# Altium schematic sheet p3_intf_conn_pwr.SchDoc(p3_intf_conn_pwr)
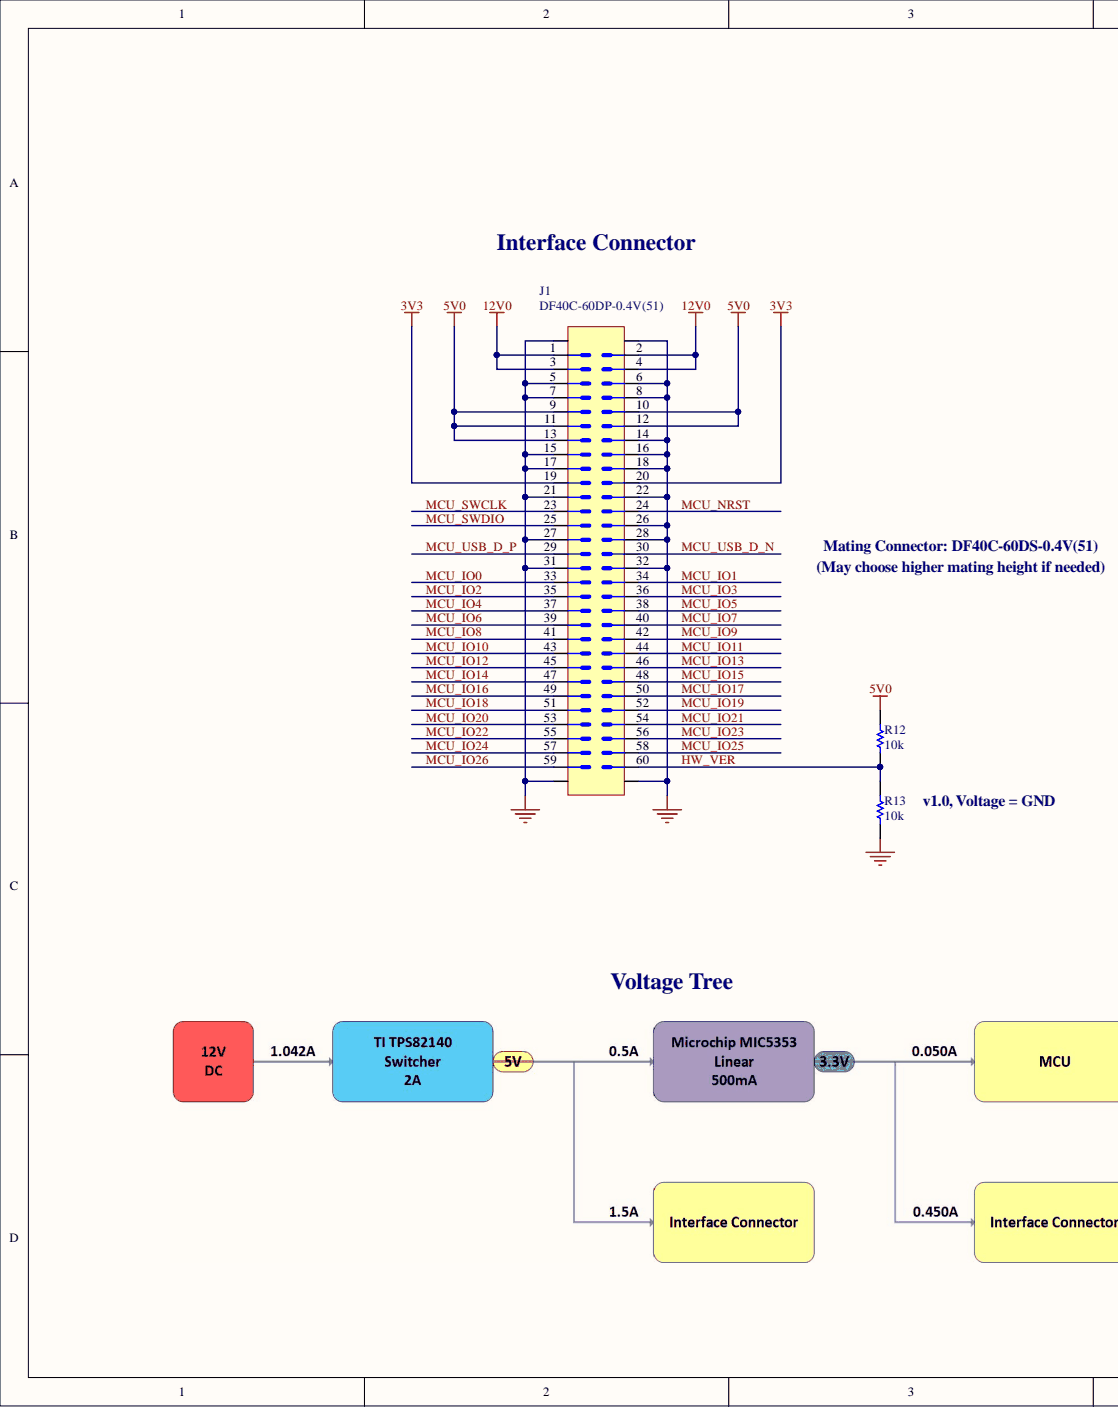
[diagram p0: p3_intf_conn_pwr.SchDoc, left]
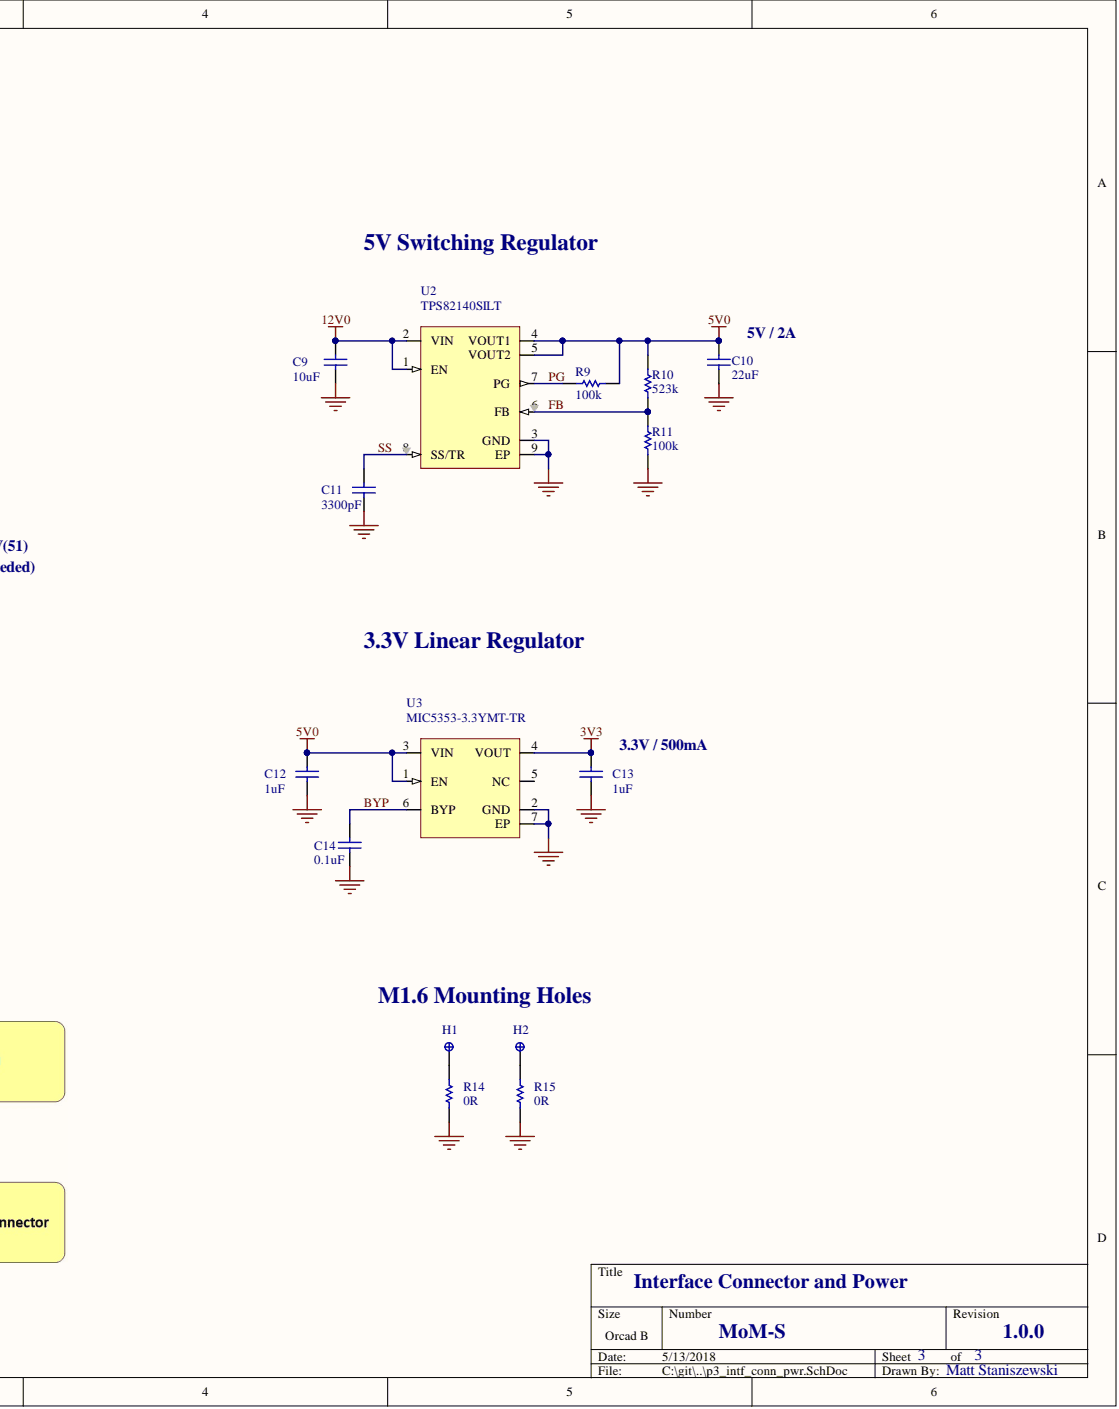
[diagram p1: p3_intf_conn_pwr.SchDoc, right]

## Parts
C9  10uF  [C0603]
C10  22uF  [C0603]
C11  3300pF  [C0201]
C12  1uF  [C0402]
C13  1uF  [C0402]
C14  0.1uF  [C0201]
H1  M1.6 MNT HOLE  [MNT_HOLE_M1.6]  (value not drawn)
H2  M1.6 MNT HOLE  [MNT_HOLE_M1.6]  (value not drawn)
J1  DF40C-60DP-0.4V(51)  [HRS_DF40C_60P_PLG]
R9  100k  [R0201]
R10  523k  [R0201]
R11  100k  [R0201]
R12  10k  [R0201]
R13  10k  [R0201]
R14  0R  [R0201]
R15  0R  [R0201]
U2  TPS82140SILT  [TI_USIL8_SIL0008D]
U3  MIC5353-3.3YMT-TR  [MCP_MLF6_MT]

## Nets
3V3: C13.2 J1.19 J1.20 U3.4(VOUT)
5V0: C10.2 C12.2 J1.9 J1.10 J1.11 J1.12 J1.13 R9.2 R10.2 R12.2 U2.4(VOUT1) U2.5(VOUT2) U3.1(EN) U3.3(VIN)
12V0: C9.2 J1.1 J1.2 J1.3 J1.4 U2.1(EN) U2.2(VIN)
GND: C9.1 C10.1 C11.1 C12.1 C13.1 C14.1 J1.5 J1.6 J1.7 J1.8 J1.14 J1.15 J1.16 J1.17 J1.18 J1.21 J1.22 J1.26 J1.27 J1.28 J1.31 J1.32 J1.61 J1.62 J1.63 J1.64 R11.1 R13.1 R14.1 R15.1 U2.3(GND) U2.9(EP) U3.2(GND) U3.7(EP)
BYP: C14.2 U3.6(BYP)
FB: R10.1 R11.2 U2.6(FB)
HW_VER: J1.60 R12.1 R13.2
MCU_IO0: J1.33
MCU_IO1: J1.34
MCU_IO2: J1.35
MCU_IO3: J1.36
MCU_IO4: J1.37
MCU_IO5: J1.38
MCU_IO6: J1.39
MCU_IO7: J1.40
MCU_IO8: J1.41
MCU_IO9: J1.42
MCU_IO10: J1.43
MCU_IO11: J1.44
MCU_IO12: J1.45
MCU_IO13: J1.46
MCU_IO14: J1.47
MCU_IO15: J1.48
MCU_IO16: J1.49
MCU_IO17: J1.50
MCU_IO18: J1.51
MCU_IO19: J1.52
MCU_IO20: J1.53
MCU_IO21: J1.54
MCU_IO22: J1.55
MCU_IO23: J1.56
MCU_IO24: J1.57
MCU_IO25: J1.58
MCU_IO26: J1.59
MCU_NRST: J1.24
MCU_SWCLK: J1.23
MCU_SWDIO: J1.25
MCU_USB_D_N: J1.30
MCU_USB_D_P: J1.29
PG: R9.1 U2.7(PG)
SS: C11.2 U2.8(SS/TR)
NetH1_1: H1.1 R14.2
NetH2_1: H2.1 R15.2

Not connected: U3 5(NC)

## Library data of the parts
C9 = 10uF 25V 0603: CAP CER 10UF 25V X5R 0603; Manufacturer Part Number: GRM188R61E106MA73D; Manufacturer: Murata; Tolerance: 20%; Width: 0.031inch; Weight: 0.000222oz; Voltage Rating (DC): 25V; Voltage Rating: 25V; Voltage: 25V; Thickness: 0.031inch; Termination: SMD/SMT; Supplier Part Number 1: 490-7202-1-ND; Supplier 1: Digi-Key; Stock 1: 1088965; REACH SVHC: No SVHC; Pricing 1: 1=0.41, 10=0.285, 100=0.1708, 500=0.1281, 1000=0.1082 (USD); Packaging: Tape and Reel; Package Quantity: 1; Number of Pins: 2; Mount: Surface Mount; Min Operating Temperature: -55°C; Max Operating Temperature: 85°C; Library Name: Capacitors.SchLib; Length: 1.6mm; Lead Free: Lead Free; Height: 0.8mm; Dielectric: X5R; Depth: 0.8mm; Composition: Ceramic; ComponentLink5URL: https://datasheet.ciiva.com/14906/partnumbering-e-01-14906431.pdf; ComponentLink5Description: https://datasheet.ciiva.com/14906/partnumbering-e-01-14906431.pdf; ComponentLink4URL: https://datasheet.ciiva.com/14906/partnumbering-e-01-14906429.pdf; ComponentLink4Description: https://datasheet.ciiva.com/14906/partnumbering-e-01-14906429.pdf; ComponentLink3URL: https://datasheet.ciiva.com/22776/c02e-22776427.pdf; ComponentLink3Description: https://datasheet.ciiva.com/22776/c02e-22776427.pdf; ComponentLink2URL: https://datasheet.ciiva.com/22776/608985-22776426.pdf; ComponentLink2Description: https://datasheet.ciiva.com/22776/608985-22776426.pdf; ComponentLink1URL: https://datasheet.ciiva.com/22619/c02e-22619674.pdf; ComponentLink1Description: https://datasheet.ciiva.com/22619/c02e-22619674.pdf; Component Kind: Standard; Case Code (Metric): 1608; Case Code (Imperial): 0603; Case/Package: 0603; Capacitance: 10uF
C10 = 22uF 16V 0603: CAP CER 22UF 16V X5R 0603; Manufacturer Part Number: CL10A226MO7JZNC; Manufacturer: Samsung; Supplier Part Number 1: 1276-7076-1-ND; Supplier 1: Digi-Key; Stock 1: 0; Library Name: Capacitors.SchLib; Component Kind: Standard
C11 = 3300pF 16V 0201: CAP CER 3300PF 16V X7R 0201; Manufacturer Part Number: GRM033R71C332KA88D; Manufacturer: Murata; Tolerance: 10%; Material: Ceramic; Capacitance: 3.3nF; Width: 0.012inch; Voltage Rating (DC): 16V; Voltage Rating: 16V; Thickness: 0.013inch; Termination: SMD/SMT; Supplier Part Number 1: 490-3191-1-ND; Supplier 1: Digi-Key; Stock 1: 49387; Radiation Hardening: No; Pricing 1: 1=0.1, 10=0.029, 100=0.013, 500=0.0093, 1000=0.0073, 2500=0.0067, 5000=0.0061 (USD); Packaging: Tape and Reel; Package Quantity: 1; Mount: Surface Mount; Min Operating Temperature: -55°C; Max Operating Temperature: 125°C; Library Name: Capacitors.SchLib; Length: 0.6mm; Lead Free: Lead Free; Height: 0.3mm; Dielectric: X7R; Depth: 0.3mm; ComponentLink6URL: https://datasheet.ciiva.com/29199/455779-da-01-en-vs-kondensator-0201-29199166.pdf; ComponentLink6Description: https://datasheet.ciiva.com/29199/455779-da-01-en-vs-kondensator-0201-29199166.pdf; ComponentLink5URL: https://datasheet.ciiva.com/129/grm033r71c332ka88-01-129902.pdf; ComponentLink5Description: https://datasheet.ciiva.com/129/grm033r71c332ka88-01-129902.pdf; ComponentLink4URL: https://datasheet.ciiva.com/18184/getdatasheetpartid-467171-18184093.pdf; ComponentLink4Description: https://datasheet.ciiva.com/18184/getdatasheetpartid-467171-18184093.pdf; ComponentLink3URL: https://datasheet.ciiva.com/129/grm033r71c332ka88-01-129901.pdf; ComponentLink3Description: https://datasheet.ciiva.com/129/grm033r71c332ka88-01-129901.pdf; ComponentLink2URL: https://datasheet.ciiva.com/129/grm033r71c332ka88-01-129900.pdf; ComponentLink2Description: https://datasheet.ciiva.com/129/grm033r71c332ka88-01-129900.pdf; ComponentLink1URL: https://datasheet.ciiva.com/11620/product-11620449.pdf; ComponentLink1Description: https://datasheet.ciiva.com/11620/product-11620449.pdf; Component Kind: Standard; Case Code (Metric): 0603; Case Code (Imperial): 0201; Case/Package: 0201
C12 C13 = 1uF 25V 0402: CAP CER 1UF 25V X5R 0402; Manufacturer Part Number: TMK105BJ105MV-F; Manufacturer: Taiyo Yuden; Tolerance: 20%; Width: 0.02inch; Voltage Rating (DC): 25V; Voltage Rating: 25V; Thickness: 0.022inch; Termination: SMD/SMT; Supplier Part Number 1: 587-3245-1-ND; Supplier 1: Digi-Key; Stock 1: 113342; Radiation Hardening: No; Pricing 1: 1=0.2, 10=0.14, 100=0.066, 500=0.0463, 1000=0.0383, 2500=0.0357, 5000=0.0326 (USD); Packaging: Tape and Reel; Package Quantity: 1; Mount: Surface Mount; Min Operating Temperature: -55°C; Max Operating Temperature: 85°C; Library Name: Capacitors.SchLib; Length: 1mm; Height: 0.5mm; Halogen Free: Halogen Free; Dielectric: X5R; Depth: 0.5mm; Composition: Ceramic; ComponentLink4URL: https://datasheet.ciiva.com/30154/128tmk105bj105mv-f-30154639.pdf; ComponentLink4Description: https://datasheet.ciiva.com/30154/128tmk105bj105mv-f-30154639.pdf; ComponentLink3URL: https://datasheet.ciiva.com/13404/mlcc-all-e-541559-13404206.pdf; ComponentLink3Description: https://datasheet.ciiva.com/13404/mlcc-all-e-541559-13404206.pdf; ComponentLink2URL: https://datasheet.ciiva.com/15922/tmk105bj105mv-f-pdf-15922317.pdf; ComponentLink2Description: https://datasheet.ciiva.com/15922/tmk105bj105mv-f-pdf-15922317.pdf; ComponentLink1URL: https://datasheet.ciiva.com/11596/tmk105bj105mv-f-ss-11596466.pdf; ComponentLink1Description: https://datasheet.ciiva.com/11596/tmk105bj105mv-f-ss-11596466.pdf; Component Kind: Standard; Case Code (Metric): 1005; Case Code (Imperial): 0402; Case/Package: 0402; Capacitance: 1uF
C14 = 0.1uF 16V 0201: CAP CER 0.1UF 16V X5R 0201; Manufacturer Part Number: GRM033R61C104ME84D; Manufacturer: Murata; Tolerance: 20%; Capacitance: 1E-07f; Width: 0.3mm; Weight: 1.2E-05oz; Voltage Rating (DC): 16V; Voltage Rating: 16V; Thickness: 0.013inch; Termination: SMD/SMT; Supplier Part Number 1: 490-9986-1-ND; Supplier 1: Digi-Key; Stock 1: 660567; Pricing 1: 1=0.1, 10=0.029, 100=0.013, 500=0.0093, 1000=0.0073, 2500=0.0067, 5000=0.0061 (USD); Packaging: Tape and Reel; Package Quantity: 15000; Min Operating Temperature: -55°C; Max Operating Temperature: 85°C; Library Name: Capacitors.SchLib; Length: 0.024inch; Height: 0.3mm; Dielectric: X5R; ComponentLink1URL: http://www.mouser.com/ds/2/281/c02e-2905.pdf; ComponentLink1Description: http://www.mouser.com/ds/2/281/c02e-2905.pdf; Component Kind: Standard; Case Code (Metric): 0603; Case Code (Imperial): 0201; Case/Package: 0201
H1 H2 = M1.6 MNT HOLE: M1.6 Mounting Hole; Library Name: Misc.SchLib; Component Kind: Standard
J1 = 60P 0.4mm Hirose Conn Plug: CONN HDR 60POS 0.4MM SMD GOLD; Manufacturer: Hirose; Voltage Rating: 30V; Stack Height: 3.5mm; Number of Positions: 60; Gender: Male; Current Rating: 300mA; Connector Type: Plug; Termination: SMD/SMT; Supplier Part Number 1: H11628CT-ND; Supplier 1: Digi-Key; Stock 1: 18849; REACH SVHC: No SVHC; Pricing 1: 1=1.24, 10=1.094, 25=1.0296, 50=0.9868, 100=0.9438, 250=0.858, 500=0.7722, 1000=0.6864, 2500=0.6221 (USD); Pitch: 0.4mm; Packaging: Tape and Reel; Package Quantity: 5000; Number of Rows: 2; Number of Contacts: 60; Mount: Surface Mount; Manufacturer Part Number: DF40C-60DP-0.4V(51); Library Name: Connectors.SchLib; Lead Free: Lead Free; Housing Material: Polymer; Contact Plating: Gold; Contact Material: Bronze; ComponentLink1URL: https://datasheet.ciiva.com/46408/1824826-46408908.pdf; ComponentLink1Description: https://datasheet.ciiva.com/46408/1824826-46408908.pdf; Component Kind: Standard; Case/Package: SMD/SMT
R9 R11 = 100k 0201: RES SMD 100K OHM 1% 1/20W 0201; Manufacturer Part Number: ERJ-1GEF1003C; Manufacturer: Panasonic ECG; Voltage Rating (DC): 15V; Voltage Rating: 25V; Temperature Coefficient: 200ppm/°C; Resistance: 100KR; Power Rating: 50mW; Width: 0.012inch; Tolerance: 1%; Termination: SMD/SMT; Supplier Part Number 1: P100KABCT-ND; Supplier 1: Digi-Key; Stock 1: 4025669; REACH SVHC: Unknown; Radiation Hardening: No; Pricing 1: 1=0.1, 10=0.059, 100=0.024, 1000=0.0108, 2500=0.0094, 5000=0.0077 (USD); Packaging: Tape and Reel; Package Quantity: 1; Number of Terminations: 2; Mount: Surface Mount; Min Operating Temperature: -55°C; Military Standard: Not; Max Power Dissipation: 50mW; Max Operating Temperature: 125°C; Library Name: Resistors.SchLib; Length: 0.6mm; Lead Free: Lead Free; Height: 0.01inch; Depth: 0.3mm; Contact Plating: Tin; Composition: Thick Film; ComponentLink8URL: https://datasheet.ciiva.com/30087/aoa0000ce2-30087131.pdf; ComponentLink8Description: https://datasheet.ciiva.com/30087/aoa0000ce2-30087131.pdf; ComponentLink7URL: https://datasheet.ciiva.com/28514/1694929-28514010.pdf; ComponentLink7Description: https://datasheet.ciiva.com/28514/1694929-28514010.pdf; ComponentLink6URL: https://datasheet.ciiva.com/16782/70260683-16782969.pdf; ComponentLink6Description: https://datasheet.ciiva.com/16782/70260683-16782969.pdf; ComponentLink5URL: https://datasheet.ciiva.com/16205/erj-1gef1003c-16205689.pdf; ComponentLink5Description: https://datasheet.ciiva.com/16205/erj-1gef1003c-16205689.pdf; ComponentLink4URL: https://datasheet.ciiva.com/7830/1678796-7830953.pdf; ComponentLink4Description: https://datasheet.ciiva.com/7830/1678796-7830953.pdf; ComponentLink3URL: https://datasheet.ciiva.com/2439/erj-g-r-e-rev08-2439267.pdf; ComponentLink3Description: https://datasheet.ciiva.com/2439/erj-g-r-e-rev08-2439267.pdf; ComponentLink2URL: https://datasheet.ciiva.com/2439/erj-g-r-e-rev08-2439266.pdf; ComponentLink2Description: https://datasheet.ciiva.com/2439/erj-g-r-e-rev08-2439266.pdf; ComponentLink1URL: https://datasheet.ciiva.com/2439/erj-g-r-e-rev08-2439265.pdf; ComponentLink1Description: https://datasheet.ciiva.com/2439/erj-g-r-e-rev08-2439265.pdf; Component Kind: Standard; Case Code (Metric): 0603; Case Code (Imperial): 0201; Case/Package: 0201
R10 = 523k 0201: RES SMD 523K OHM 1% 1/20W 0201; Manufacturer Part Number: ERJ-1GEF5233C; Manufacturer: Panasonic ECG; Voltage Rating (DC): 15V; Voltage Rating: 25V; Temperature Coefficient: 200ppm/°C; Resistance: 523KR; Power Rating: 50mW; Width: 0.012inch; Tolerance: 1%; Supplier Part Number 1: P523KABCT-ND; Supplier 1: Digi-Key; Stock 1: 42844; Pricing 1: 1=0.1, 10=0.059, 100=0.024, 1000=0.0108, 2500=0.0094, 5000=0.0077 (USD); Packaging: Tape and Reel; Package Quantity: 1; Number of Terminations: 2; Mount: Surface Mount; Min Operating Temperature: -55°C; Max Power Dissipation: 50mW; Max Operating Temperature: 125°C; Library Name: Resistors.SchLib; Length: 0.024inch; Lead Free: Lead Free; Height: 0.01inch; Contact Plating: Tin; Composition: Thick Film; ComponentLink3URL: https://datasheet.ciiva.com/2440/erj-g-r-e-rev08-2440227.pdf; ComponentLink3Description: https://datasheet.ciiva.com/2440/erj-g-r-e-rev08-2440227.pdf; ComponentLink2URL: https://datasheet.ciiva.com/2440/erj-g-r-e-rev08-2440226.pdf; ComponentLink2Description: https://datasheet.ciiva.com/2440/erj-g-r-e-rev08-2440226.pdf; ComponentLink1URL: https://datasheet.ciiva.com/2440/erj-g-r-e-rev08-2440225.pdf; ComponentLink1Description: https://datasheet.ciiva.com/2440/erj-g-r-e-rev08-2440225.pdf; Component Kind: Standard; Case Code (Metric): 0603; Case Code (Imperial): 0201; Case/Package: 0201
R12 R13 = 10k 0201: RES SMD 10K OHM 1% 1/20W 0201; Manufacturer Part Number: ERJ-1GEF1002C; Manufacturer: Panasonic ECG; Voltage Rating (DC): 15V; Voltage Rating: 25V; Temperature Coefficient: 200ppm/°C; Resistance: 10KR; Power Rating: 50mW; Width: 0.012inch; Tolerance: 1%; Termination: SMD/SMT; Supplier Part Number 1: P10.0KABCT-ND; Supplier 1: Digi-Key; Stock 1: 4709989; REACH SVHC: Unknown; Radiation Hardening: No; Pricing 1: 1=0.1, 10=0.059, 100=0.024, 1000=0.0108, 2500=0.0094, 5000=0.0077 (USD); Packaging: Tape and Reel; Package Quantity: 15000; Number of Terminations: 2; Mount: Surface Mount; Min Operating Temperature: -55°C; Military Standard: Not; Max Power Dissipation: 50mW; Max Operating Temperature: 125°C; Library Name: Resistors.SchLib; Length: 0.6mm; Lead Free: Lead Free; Height: 0.23mm; Depth: 0.3mm; Contact Plating: Tin; Composition: Thick Film; ComponentLink6URL: https://datasheet.ciiva.com/16770/70260683-16770095.pdf; ComponentLink6Description: https://datasheet.ciiva.com/16770/70260683-16770095.pdf; ComponentLink5URL: https://datasheet.ciiva.com/7830/1678796-7830952.pdf; ComponentLink5Description: https://datasheet.ciiva.com/7830/1678796-7830952.pdf; ComponentLink4URL: https://datasheet.ciiva.com/22785/1678796-22785991.pdf; ComponentLink4Description: https://datasheet.ciiva.com/22785/1678796-22785991.pdf; ComponentLink3URL: https://datasheet.ciiva.com/16775/70260683-16775527.pdf; ComponentLink3Description: https://datasheet.ciiva.com/16775/70260683-16775527.pdf; ComponentLink2URL: https://datasheet.ciiva.com/30111/aoa0000ce2-30111653.pdf; ComponentLink2Description: https://datasheet.ciiva.com/30111/aoa0000ce2-30111653.pdf; ComponentLink1URL: https://datasheet.ciiva.com/16427/erj-1gef1002c-16427252.pdf; ComponentLink1Description: https://datasheet.ciiva.com/16427/erj-1gef1002c-16427252.pdf; Component Kind: Standard; Case Code (Metric): 0603; Case Code (Imperial): 0201; Case/Package: 0201
R14 R15 = 0R 0201: RES SMD 0 OHM JUMPER 1/20W 0201; Manufacturer Part Number: ERJ-1GN0R00C; Manufacturer: Panasonic; Voltage Rating (DC): 25V; Tolerance: 1%; Temperature Coefficient: 600ppm/°C; Resistance: 0mR; Power Rating: 50mW; Width: 0.3mm; Voltage Rating: 25V; Supplier Part Number 1: P15979CT-ND; Supplier 1: Digi-Key; Stock 1: 7705948; REACH SVHC: Unknown; Pricing 1: 1=0.1, 10=0.028, 25=0.0204, 50=0.0154, 100=0.0114, 250=0.00872, 500=0.00696, 1000=0.00513, 5000=0.00368 (USD); Packaging: Tape and Reel; Package Quantity: 15000; Mount: Surface Mount; Min Operating Temperature: -55°C; Military Standard: Not; Max Operating Temperature: 125°C; Library Name: Resistors.SchLib; Length: 0.6mm; Lead Free: Lead Free; Height: 0.23mm; Depth: 0.3mm; Contact Plating: Tin; Composition: Thick Film; ComponentLink1URL: https://datasheet.ciiva.com/22730/1678796-22730749.pdf; ComponentLink1Description: https://datasheet.ciiva.com/22730/1678796-22730749.pdf; Component Kind: Standard; Case Code (Metric): 0603; Case Code (Imperial): 0201; Case/Package: 0201
U2 = TPS82140SILT: STEP-DOWN CONVERTER MICROSIP; Manufacturer: Texas Instruments; Supplier Part Number 1: 296-47089-1-ND; Supplier 1: Digi-Key; Stock 1: 0; Min Operating Temperature: -40°C; Max Operating Temperature: 125°C; Manufacturer Part Number: TPS82140SILT; Library Name: ICs.SchLib; Component Kind: Standard
U3 = MIC5353-3.3YMT-TR: IC REG LINEAR 3.3V 500MA 6TMLF; Manufacturer: Microchip; Number of Regulators: 1; Min Input Voltage: 2.6V; Min Current Limit: 600mA; Max Input Voltage: 6V; Dropout Voltage: 160mV; Supplier Part Number 1: 576-3680-1-ND; Supplier 1: Digi-Key; Stock 1: 24501; REACH SVHC: No SVHC; Pricing 1: 1=0.36, 25=0.294, 100=0.273 (USD); Packaging: Cut Tape; Output Voltage: 3.3V; Output Current: 500mA; Number of Pins: 6; Nominal Output Voltage: 3.3V; Min Operating Temperature: -40°C; Max Operating Temperature: 125°C; Manufacturer Part Number: MIC5353-3.3YMT-TR; Library Name: ICs.SchLib; Lead Free: Lead Free; ComponentLink1URL: http://www.farnell.com/datasheets/1692673.pdf; ComponentLink1Description: http://www.farnell.com/datasheets/1692673.pdf; Component Kind: Standard
